# Altium schematic sheet POWER.SchDoc(POWER)
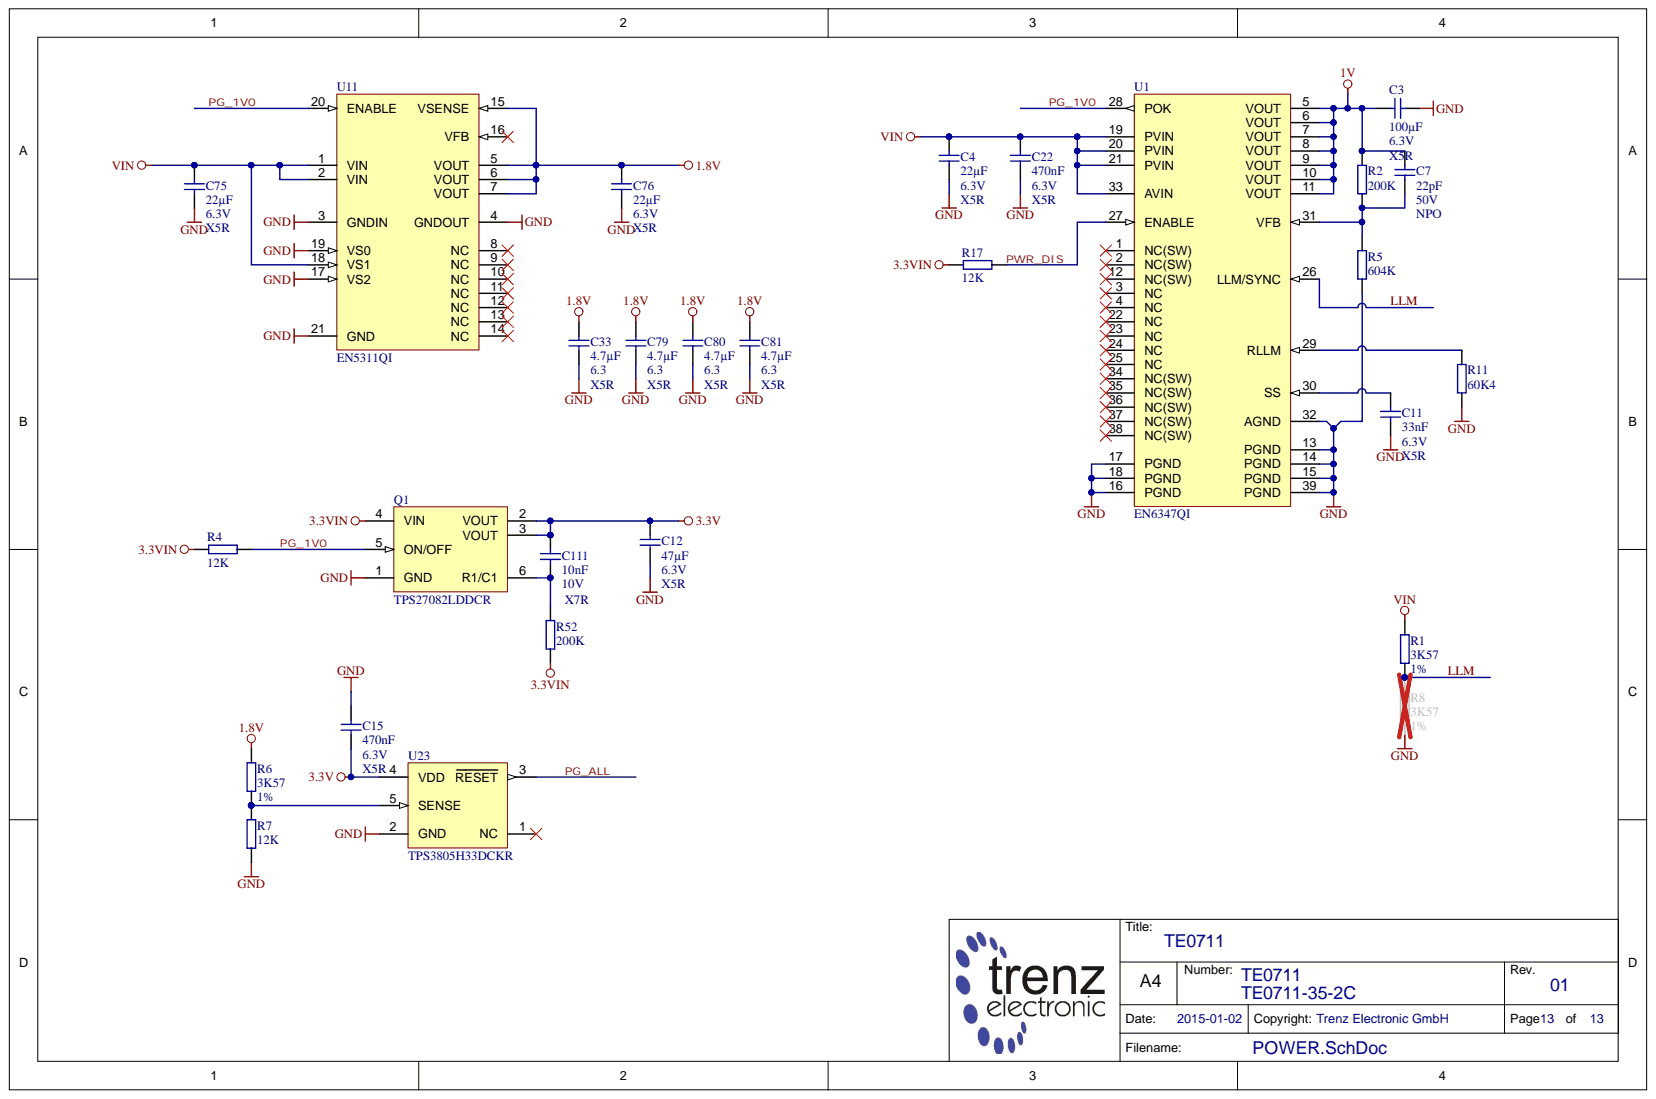
[diagram p0: POWER.SchDoc]

## Parts
C3  100µF  [CAPC3216X180N]
C4  22µF  Technology: X5R  Voltage: 6.3V  [CAPC2012X135N]
C7  22pF  Technology: NPO  Voltage: 50V  [CAPC1005X55N]
C11  33nF  Technology: X5R  Voltage: 6.3V  [CAPC0603X33N]
C12  47µF  Technology: X5R  Voltage: 6.3V  [CAPC2012X135N]
C15  470nF  Voltage: 6.3V  [CAPC0603X33N]
C22  470nF  Technology: X5R  Voltage: 6.3V  [CAPC0603X33N]
C33  4.7µF  Technology: X5R  Voltage: 6.3  [CAPC1005X60N]
C75  22µF  Technology: X5R  Voltage: 6.3V  [CAPC2012X135N]
C76  22µF  Technology: X5R  Voltage: 6.3V  [CAPC2012X135N]
C79  4.7µF  Technology: X5R  Voltage: 6.3  [CAPC1005X60N]
C80  4.7µF  Technology: X5R  Voltage: 6.3  [CAPC1005X60N]
C81  4.7µF  Technology: X5R  Voltage: 6.3  [CAPC1005X60N]
C111  10nF  Voltage: 10V  [CAPC0603X33N]
Q1  TPS27082LDDCR  [SOT95P280X110-6N]
R1  3K57  Tolerance: 1%  [RESC1005X40N]
R2  200K  [RESC1005X40N]
R4  12K  [RESC1005X40N]
R5  604K  [RESC1005X40N]
R6  3K57  Tolerance: 1%  [RESC1005X40N]
R7  12K  [RESC1005X40N]
R8  3K57  Tolerance: 1%  [RESC1005X40N]
R11  60K4  [RESC1005X40N]
R17  12K  [RESC1005X40N]
R52  200K  [RESC1005X40N]
U1  EN6347QI  [EN63xx]
U11  EN5311QI  [EN5311QI]
U23  TPS3805H33DCKR  [SOT65P210X110-5N]

## Nets
1.8V: C33.1 C76.1 C79.1 C80.1 C81.1 R6.1 U11.5(VOUT) U11.6(VOUT) U11.7(VOUT) U11.15(VSENSE)
1V: C3.1 C7.1 R2.1 U1.5(VOUT) U1.6(VOUT) U1.7(VOUT) U1.8(VOUT) U1.9(VOUT) U1.10(VOUT) U1.11(VOUT)
3.3V: C12.2 C15.2 C111.1 Q1.2(VOUT) Q1.3(VOUT) U23.4(VDD)
3.3VIN: Q1.4(VIN) R4.1 R17.1 R52.2
GND: C3.2 C4.2 C11.2 C12.1 C15.1 C22.1 C33.2 C75.2 C76.2 C79.2 C80.2 C81.2 Q1.1(GND) R5.2 R7.2 R8.1 R11.1 U1.13(PGND) U1.14(PGND) U1.15(PGND) U1.16(PGND) U1.17(PGND) U1.18(PGND) U1.32(AGND) U1.39(PGND) U11.3(GNDIN) U11.4(GNDOUT) U11.17(VS2) U11.19(VS0) U11.21(GND) U23.2(GND)
VIN: C4.1 C22.2 C75.1 R1.2 U1.19(PVIN) U1.20(PVIN) U1.21(PVIN) U1.33(AVIN) U11.1(VIN) U11.2(VIN) U11.18(VS1)
LLM: R1.1 R8.2 U1.26(LLM/SYNC)
PG_1V0: Q1.5(ON/OFF) R4.2 U1.28(POK) U11.20(ENABLE)
PG_ALL: U23.3(RESET)
PWR_DIS: R17.2 U1.27(ENABLE)
NetC7_2: C7.2 R2.2 R5.1 U1.31(VFB)
NetC11_1: C11.1 U1.30(SS)
NetC111_2: C111.2 Q1.6(R1/C1) R52.1
NetR6_2: R6.2 R7.1 U23.5(SENSE)
NetR11_2: R11.2 U1.29(RLLM)

Not connected: U1 1(NC(SW)) 2(NC(SW)) 3(NC) 4(NC) 12(NC(SW)) 22(NC) 23(NC) 24(NC) 25(NC) 34(NC(SW)) 35(NC(SW)) 36(NC(SW)) 37(NC(SW)) 38(NC(SW)); U11 8(NC) 9(NC) 10(NC) 11(NC) 12(NC) 13(NC) 14(NC) 16(VFB); U23 1(NC)

## Library data of the parts
C3 = 23116: KON 100µF 6,3V X5R SMD; Database Table Name: C_3216_1206; Mixed: SMT; Preferred: Yes; Value: 100u; Voltage: 6.3V; AN: 23116; Case: 3216 (1206); Component Kind: Standard; Library Name: TE.DbLib; Technology: X5R
C4 C75 C76 = 23410: KON 22µF 6,3V  X5R SMD; Database Table Name: C_2012_0805; Mixed: SMT; Value: 22u; AN: 23410; Case: 2012 (0805); Component Kind: Standard; Library Name: TE.DbLib
C7 = 22581: KON 22pF NPO 50V SMD; Database Table Name: C_1005_0402; Mixed: SMT; Preferred: Yes; Value: 22p; AN: 22581; Case: 1005 (0402); Component Kind: Standard; Library Name: TE.DbLib
C11 = 24923: KON 33nF X5R 6.3V SMD; Database Table Name: C_0603_0201; Mixed: SMT; Preferred: Yes; Tolerance: 10%; Value: 33n; AN: 24923; Case: 0603 (0201); Component Kind: Standard; Library Name: TE.DbLib
C12 = 24718: KON 47µF 6,3V  X5R SMD 20%; Database Table Name: C_2012_0805; Mixed: SMT; Preferred: Yes; Value: 47u; AN: 24718; Case: 2012 (0805); Component Kind: Standard; Library Name: TE.DbLib; Tolerance: 20%
C15 C22 = 24921: KON 470nF X5R 6.3V SMD; Database Table Name: C_0603_0201; Mixed: SMT; Preferred: Yes; Tolerance: 20%; Value: 470n; AN: 24921; Case: 0603 (0201); Component Kind: Standard; Library Name: TE.DbLib
  C15: Technology: X5R
C33 C79-C81 = 24719: KON 4.7µF 6.3V X5R SMD; Database Table Name: C_1005_0402; Mixed: SMT; Preferred: Yes; Value: 4.7u; AN: 24719; Case: 1005 (0402); Component Kind: Standard; Library Name: TE.DbLib
C111 = 26312: KON 10nF X7R 10V SMD 10%; Database Table Name: C_0603_0201; Mixed: SMT; Preferred: Yes; Value: 10n; AN: 26312; Case: 0603 (0201); Component Kind: Standard; Library Name: TE.DbLib; Technology: X7R; Tolerance: 10%
Q1 = 25004: Database Table Name: SWITCH; Mixed: SMT; Preferred: Yes; AN: 25004; Case: SOT23-6; Component Kind: Standard; Description: TPS27082LDDCR; Library Name: TE.DbLib; Trenz-Stock: Yes; HelpURL: B:\AD\adlib\datasheet\TPS27082L
R1 R6 R8 = 23145: WID SMD 3K57 1% 0,063W; Database Table Name: R_1005_0402; Mixed: SMT; Value: 3.57K; AN: 23145; Case: 1005 (0402); Component Kind: Standard; Library Name: TE.DbLib
R2 R52 = 23846: WID SMD 200K 1% 0,063W; Database Table Name: R_1005_0402; Mixed: SMT; Preferred: Yes; AN: 23846; Case: 1005 (0402); Component Kind: Standard; Library Name: TE.DbLib; Value: 200K
R4 R7 R17 = 22598: WID SMD 12K 1% 0,063W; Database Table Name: R_1005_0402; Mixed: SMT; Preferred: Yes; AN: 22598; Case: 1005 (0402); Component Kind: Standard; Library Name: TE.DbLib; Value: 12K
R5 = 24712: WID SMD 604K 1% 0,063W; Database Table Name: R_1005_0402; Mixed: SMT; Preferred: Yes; AN: 24712; Case: 1005 (0402); Component Kind: Standard; Library Name: TE.DbLib; Value: 604k
R11 = 23156: WID SMD 60K4 1% 0,063W; Database Table Name: R_1005_0402; Mixed: SMT; Value: 60.4K; AN: 23156; Case: 1005 (0402); Component Kind: Standard; Library Name: TE.DbLib
U1 = 24486: Database Table Name: DCDC; Mixed: SMT; Preferred: Yes; AN: 24486; Component Kind: Standard; Description: EN6347QI; Library Name: TE.DbLib; Trenz-Stock: Yes; HelpURL: B:\AD\adlib\datasheet\EN6347QI
U11 = 25492: Database Table Name: DCDC; Mixed: SMT; Preferred: Yes; AN: 25492; Case: QFN20; Component Kind: Standard; Description: EN5311QI; Library Name: TE.DbLib; Trenz-Stock: Yes; HelpURL: B:\AD\adlib\datasheet\EN5311QI
U23 = 25049: Database Table Name: SUPERVISOR; Mixed: SMT; Preferred: Yes; AN: 25049; Case: SC70-5 / SC88A / SOT353; Component Kind: Standard; Description: TPS3805H33DCKR; Library Name: TE.DbLib; Trenz-Stock: Yes; HelpURL: B:\AD\adlib\datasheet\TPS3805H33
